# Altium schematic sheet ADC.SchDoc(ADC)
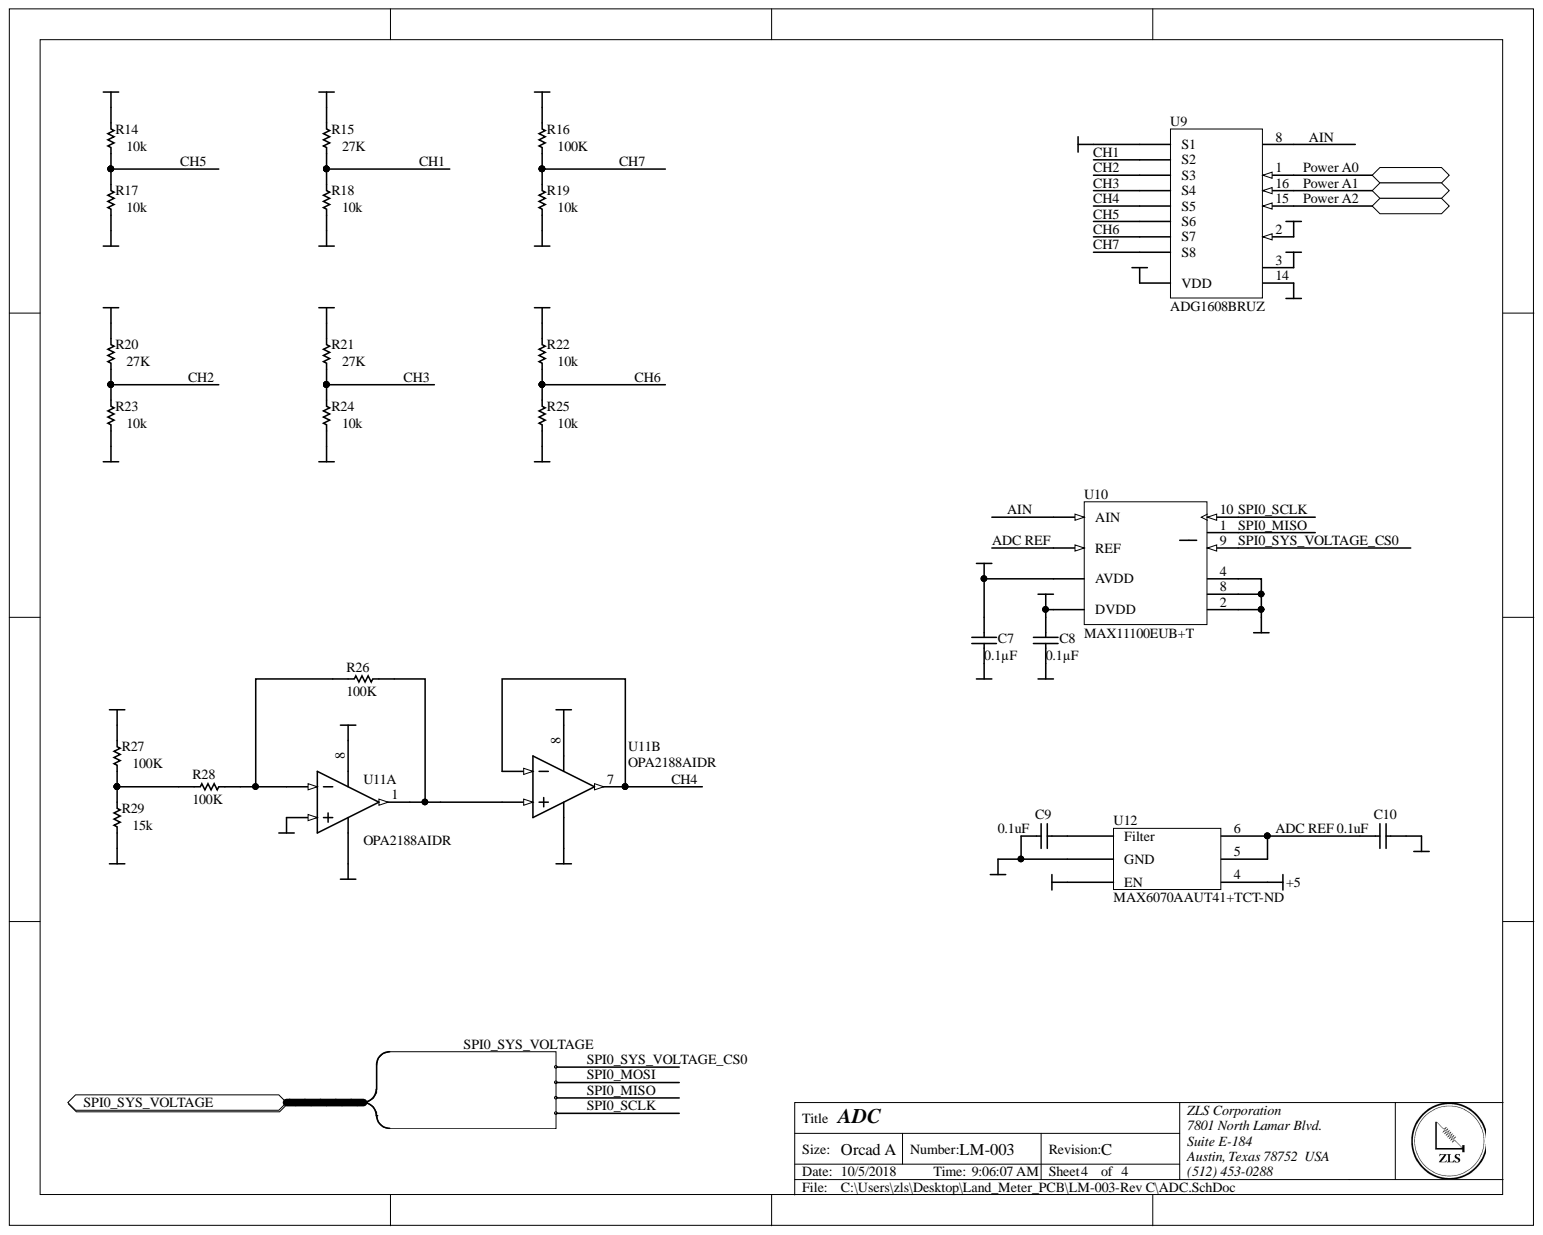
[diagram p0: ADC.SchDoc]

## Parts
C7  Capacitance: 0.1µF  [CAPC0805(2012)145_N]  (hidden comment: CAP CER 0.1UF 25V X7R 0805)
C8  Capacitance: 0.1µF  [CAPC0805(2012)145_N]  (hidden comment: CAP CER 0.1UF 25V X7R 0805)
C9  0.1uF  [CAPC0402(1005)60_L]
C10  0.1uF  [CAPC0402(1005)60_L]
R14  10k  [RESC0402(1005)_L]
R15  27K  [RESC0402(1005)_L]
R16  100K  [RESC0402(1005)_L]
R17  10k  [RESC0402(1005)_L]
R18  10k  [RESC0402(1005)_L]
R19  10k  [RESC0402(1005)_L]
R20  27K  [RESC0402(1005)_L]
R21  27K  [RESC0402(1005)_L]
R22  10k  [RESC0402(1005)_L]
R23  10k  [RESC0402(1005)_L]
R24  10k  [RESC0402(1005)_L]
R25  10k  [RESC0402(1005)_L]
R26  100K  [RESC0402(1005)_L]
R27  100K  [RESC0402(1005)_L]
R28  100K  [RESC0402(1005)_L]
R29  15k  [RESC0402(1005)_L]
U9  ADG1608BRUZ  [ADI-RU-16_M]
U10  MAX11100EUB+T  [U10+2_L]
U11  OPA2188AIDR  [D0008A_L]  (parts A,B)
U12  MAX6070AAUT41+T  Supplier Part Number 1: MAX6070AAUT41+TCT-ND  [SOT95P280X445-6N]

## Ports
Power A0, Power A1, Power A2, SPI0_SYS_VOLTAGE, SPI0_SYS_VOLTAGE.SPI0_MISO, SPI0_SYS_VOLTAGE.SPI0_MOSI, SPI0_SYS_VOLTAGE.SPI0_SCLK, SPI0_SYS_VOLTAGE.SPI0_SYS_VOLTAGE_CS0

## Nets
+3.3: C8.1 U9.4(S1) U10.3(DVDD)
+5: C7.1 R22.2 U9.2 U9.13(VDD) U10.7(AVDD) U11.8 U12.3(EN) U12.4
+5 BBB: R14.2
+12: R15.2 R27.2
-5: R29.1 U9.3 U11.4
Battery_1: R20.2
Battery_2: R21.2
GND: C7.2 C8.2 C9.2 C10.1 R17.1 R18.1 R19.1 R23.1 R24.1 R25.1 U9.14 U10.2 U10.4 U10.8 U11.3 U11.4 U12.2(GND)
ZH: R16.2
ADC REF: C10.2 U10.6(REF) U12.5 U12.6
AIN: U9.8 U10.5(AIN)
CH1: R15.1 R18.2 U9.5(S2)
CH2: R20.1 R23.2 U9.6(S3)
CH3: R21.1 R24.2 U9.7(S4)
CH4: U9.12(S5) U11.6 U11.7
CH5: R14.1 R17.2 U9.11(S6)
CH6: R22.1 R25.2 U9.10(S7)
CH7: R16.1 R19.2 U9.9(S8)
Power A0: U9.1 ; port Power A0
Power A1: U9.16 ; port Power A1
Power A2: U9.15 ; port Power A2
SPI0_MISO: U10.1 ; port SPI0_SYS_VOLTAGE
SPI0_SCLK: U10.10 ; port SPI0_SYS_VOLTAGE
SPI0_SYS_VOLTAGE_CS0: U10.9 ; port SPI0_SYS_VOLTAGE
NetC9_1: C9.1 U12.1(Filter)
NetR26_1: R26.1 U11.1 U11.5
NetR26_2: R26.2 R28.1 U11.2
NetR27_1: R27.1 R28.2 R29.2
SPI0_MOSI: ; port SPI0_SYS_VOLTAGE

## Library data of the parts
C7 C8 = CMP-1036-04795-1: Manufacturer Part Number: GRM21BR71E104KA01L; Manufacturer: Murata Electronics North America; Tolerance: ±10%; Voltage - Rated: 25V; Thickness (Max): 0.053" (1.35mm); Temperature Coefficient: X7R; Supplier Part Number 1: 490-1673-6-ND; Supplier 1: Digi-Key; Stock 1: 891652; Size / Dimension: 0.079" L x 0.049" W (2.00mm x 1.25mm); RoHS: RoHS Compliant; Ratings: -; Pricing 1: 1=0.2, 10=0.145, 100=0.0683, 500=0.04788, 1000=0.03964 (USD); Part Status: Active; Packaging: Digi-Reel®; Package / Case: 0805 (2012 Metric); Operating Temperature: -55°C ~ 125°C; Mounting Type: Surface Mount, MLCC; Library Name: Altium Content Vault; Lead Style: -; Lead Spacing: -; Height - Seated (Max): -; Features: -; Description: CAP CER 0.1UF 25V X7R 0805; ComponentLink1URL: http://www.murata.com/~/media/webrenewal/support/library/catalog/products/capacitor/mlcc/c02e.ashx; ComponentLink1Description: http://www.murata.com/~/media/webrenewal/support/library/catalog/products/capacitor/mlcc/c02e.ashx; Component Kind: Standard; Category: Capacitors; Applications: General Purpose
C9 C10 = CMP-1034-00252-1: CAP CER 0.1UF 10V X7R 0402; Manufacturer Part Number: GRM155R71A104KA01D; Manufacturer: Murata Electronics North America; Tolerance: ±10%; Voltage - Rated: 10V; Thickness (Max): 0.022" (0.55mm); Temperature Coefficient: X7R; Supplier Part Number 1: 490-6321-1-ND; Supplier 1: Digi-Key; Stock 1: 2920395; Size / Dimension: 0.039" L x 0.020" W (1.00mm x 0.50mm); RoHS: RoHS Compliant; Ratings: -; Pricing 1: 1=0.1, 10=0.014, 100=0.0063, 500=0.00446, 1000=0.00351, 2500=0.00319, 5000=0.00293 (USD); Part Status: Active; Packaging: Cut Tape (CT); Package / Case: 0402 (1005 Metric); Operating Temperature: -55°C ~ 125°C; Mounting Type: Surface Mount, MLCC; Library Name: Altium Content Vault; Lead Spacing: -; Height - Seated (Max): -; Features: -; ComponentLink1URL: http://www.murata.com/~/media/webrenewal/support/library/catalog/products/capacitor/mlcc/c02e.ashx?la=en-us; ComponentLink1Description: http://www.murata.com/~/media/webrenewal/support/library/catalog/products/capacitor/mlcc/c02e.ashx?la=en-us; Component Kind: Standard; Category: Capacitors; Applications: General Purpose
R14 R17-R19 R22-R25 = CMP-1011-00927-1: RES SMD 10K OHM 1% 1/10W 0402; Manufacturer Part Number: MCS0402MC1002FE000; Manufacturer: Vishay Beyschlag; Temperature Coefficient: ±50ppm/°C; Power (Watts): 0.1W, 1/10W; Tolerance: ±1%; Supplier Part Number 1: MCS0402-10.0K-MFCT-ND; Supplier Device Package: 0402; Supplier 1: Digi-Key; Stock 1: 167944; Size / Dimension: 0.039" L x 0.020" W (1.00mm x 0.50mm); RoHS: RoHS Compliant; Pricing 1: 1=0.3, 10=0.254, 100=0.0993, 1000=0.04158, 2500=0.03812, 5000=0.03465 (USD); Part Status: Active; Packaging: Cut Tape (CT); Package / Case: 0402 (1005 Metric); Operating Temperature: -55°C ~ 175°C; Library Name: Altium Content Vault; Height - Seated (Max): 0.015" (0.37mm); Features: Anti-Sulfur, Automotive AEC-Q200; Failure Rate: -; Composition: Thin Film; ComponentLink1URL: http://www.vishay.com/docs/28760/mcat_pro.pdf; ComponentLink1Description: http://www.vishay.com/docs/28760/mcat_pro.pdf; Component Kind: Standard; Category: Resistors
R15 R20 R21 = CMP-1011-00927-1: RES SMD 27K OHM 1% 1/10W 0402; Manufacturer Part Number: MCS04020C2702FE000; Manufacturer: Vishay Beyschlag; Temperature Coefficient: ±50ppm/°C; Power (Watts): 0.1W, 1/10W; Tolerance: ±1%; Supplier Part Number 1: 749-1569-1-ND; Supplier Device Package: 0402; Supplier 1: Digi-Key; Stock 1: 16395; Size / Dimension: 0.039" L x 0.020" W (1.00mm x 0.50mm); RoHS: RoHS Compliant; Pricing 1: 1=0.3, 10=0.254, 100=0.0993, 1000=0.04158, 2500=0.03812, 5000=0.03465 (USD); Part Status: Active; Packaging: Cut Tape (CT); Package / Case: 0402 (1005 Metric); Operating Temperature: -55°C ~ 155°C; Library Name: Altium Content Vault; Height - Seated (Max): 0.015" (0.37mm); Features: Anti-Sulfur; Failure Rate: -; Composition: Thin Film; ComponentLink1URL: http://www.vishay.com/docs/28705/mcx0x0xpro.pdf; ComponentLink1Description: http://www.vishay.com/docs/28705/mcx0x0xpro.pdf; Component Kind: Standard; Category: Resistors
R16 R26-R28 = CMP-1011-00927-1: RES SMD 100K OHM 1% 1/10W 0402; Manufacturer Part Number: MCS04020C1003FE000; Manufacturer: Vishay Beyschlag; Temperature Coefficient: ±50ppm/°C; Power (Watts): 0.1W, 1/10W; Tolerance: ±1%; Supplier Part Number 1: MCS0402-100K-CFCT-ND; Supplier Device Package: 0402; Supplier 1: Digi-Key; Stock 1: 118040; Size / Dimension: 0.039" L x 0.020" W (1.00mm x 0.50mm); RoHS: RoHS Compliant; Pricing 1: 1=0.3, 10=0.254, 100=0.0993, 1000=0.04158, 2500=0.03812, 5000=0.03465 (USD); Part Status: Active; Packaging: Cut Tape (CT); Package / Case: 0402 (1005 Metric); Operating Temperature: -55°C ~ 155°C; Library Name: Altium Content Vault; Height - Seated (Max): 0.015" (0.37mm); Features: Anti-Sulfur; Failure Rate: -; Composition: Thin Film; ComponentLink1URL: http://www.vishay.com/docs/28705/mcx0x0xpro.pdf; ComponentLink1Description: http://www.vishay.com/docs/28705/mcx0x0xpro.pdf; Component Kind: Standard; Category: Resistors
R29 = CMP-1011-00927-1: RES SMD 15K OHM 1% 1/10W 0402; Manufacturer Part Number: MCS04020C1502FE000; Manufacturer: Vishay Beyschlag; Temperature Coefficient: ±50ppm/°C; Power (Watts): 0.1W, 1/10W; Tolerance: ±1%; Supplier Part Number 1: 749-1555-1-ND; Supplier Device Package: 0402; Supplier 1: Digi-Key; Stock 1: 93804; Size / Dimension: 0.039" L x 0.020" W (1.00mm x 0.50mm); RoHS: RoHS Compliant; Pricing 1: 1=0.3, 10=0.254, 100=0.0993, 1000=0.04158, 2500=0.03812, 5000=0.03465 (USD); Part Status: Active; Packaging: Cut Tape (CT); Package / Case: 0402 (1005 Metric); Operating Temperature: -55°C ~ 155°C; Library Name: Altium Content Vault; Height - Seated (Max): 0.015" (0.37mm); Features: Anti-Sulfur; Failure Rate: -; Composition: Thin Film; ComponentLink1URL: http://www.vishay.com/docs/28705/mcx0x0xpro.pdf; ComponentLink1Description: http://www.vishay.com/docs/28705/mcx0x0xpro.pdf; Component Kind: Standard; Category: Resistors
U9 = CMP-0793-00136-1: 4.5 Ohm Ron, 8-Channel +/-5 V,+12 V, +5 V and +3.3 V Multiplexer, 16-pin SOP (RU-16), Tube; Manufacturer: Analog Devices Inc.; Voltage - Supply, Single (V+): 3.3 V ~ 16 V; Voltage - Supply, Dual (V±): ±3.3 V ~ 8 V; Switch Time (Ton, Toff) (Max): 94ns, 93ns; On-State Resistance (Max): 4.5 Ohm; Multiplexer/Demultiplexer Circuit: 8:1; Current - Leakage (IS(off)) (Max): 100pA; Crosstalk: -64dB @ 1MHz; Charge Injection: 29pC; Channel Capacitance (CS(off), CD(off)): 19pF, 117pF; Channel-to-Channel Matching (&Delta;Ron): 120 mOhm; -3db Bandwidth: 40MHz; Switch Circuit: -; Supplier Part Number 1: ADG1608BRUZ-ND; Supplier Device Package: 16-TSSOP; Supplier 1: Digi-Key; Stock 1: 1151; RoHS: RoHS Compliant; Pricing 1: 1=9.31, 96=8.01552, 192=6.95979, 576=6.0605 (USD); Part Status: Active; Packaging: Tube; Package / Case: 16-TSSOP (0.173", 4.40mm Width); Operating Temperature: -40°C ~ 125°C (TA); Manufacturer Part Number: ADG1608BRUZ; Library Name: Altium Content Vault; ComponentLink1URL: http://www.analog.com/media/en/technical-documentation/data-sheets/ADG1608_1609.pdf; ComponentLink1Description: http://www.analog.com/media/en/technical-documentation/data-sheets/ADG1608_1609.pdf; Component Kind: Standard; Category: Integrated Circuits (ICs); Base Part Number: ADG1608
U10 = CMP-0249-00017-1: IC ADC 16BIT SRL 200KSPS 10UMAX; Manufacturer: Maxim Integrated; Voltage - Supply, Digital: 2.7 V ~ 5.25 V; Voltage - Supply, Analog: 5V; Sampling Rate (Per Second): 200k; Reference Type: External; Ratio - S/H: 1:1; Number of Inputs: 1; Number of Bits: 16; Input Type: Single Ended; Data Interface: SPI; Configuration: S/H-ADC; Architecture: SAR; Supplier Part Number 1: MAX11100EUB+-ND; Supplier Device Package: 10-uMAX; Supplier 1: Digi-Key; Stock 1: 927; RoHS: RoHS Compliant; Pricing 1: 1=5.98, 10=5.855 (USD); Part Status: Active; Packaging: Tube; Package / Case: 10-TFSOP, 10-MSOP (0.118", 3.00mm Width); Operating Temperature: -40°C ~ 85°C; Manufacturer Part Number: MAX11100EUB+; Library Name: Altium Content Vault; Features: -; ComponentLink1URL: https://datasheets.maximintegrated.com/en/ds/MAX11100.pdf; ComponentLink1Description: https://datasheets.maximintegrated.com/en/ds/MAX11100.pdf; Component Kind: Standard; Category: Integrated Circuits (ICs); Base Part Number: MAX11100
U11 = CMP-0272-00241-3: 0.03 uV/degC, 6 uV Vos, Low Noise, Rail-to-Rail Output, Zero-Drift Operational Amplifier, 4 to 36 V, -40 to 105 degC, 8-pin SOIC (D8), Green (RoHS & no Sb/Br); Manufacturer: Texas Instruments; Voltage - Supply, Single/Dual (±): 4 V ~ 36 V, ±2 V ~ 18 V; Slew Rate: 0.8 V/µs; Current - Supply: 415µA; Supplier Part Number 1: 296-29454-1-ND; Supplier Device Package: 8-SOIC; Supplier 1: Digi-Key; Stock 1: 11691; RoHS: RoHS Compliant; Pricing 1: 1=3.36, 10=3.02, 100=2.4745, 500=2.10648, 1000=1.77654 (USD); Part Status: Active; Packaging: Cut Tape (CT); Package / Case: 8-SOIC (0.154", 3.90mm Width); Output Type: Rail-to-Rail; Operating Temperature: -40°C ~ 105°C; Mounting Type: Surface Mount; Manufacturer Part Number: OPA2188AIDR; Library Name: Altium Content Vault; ComponentLink1URL: http://www.ti.com/lit/ds/symlink/opa2188.pdf; ComponentLink1Description: http://www.ti.com/lit/ds/symlink/opa2188.pdf; Component Kind: Standard; Category: Integrated Circuits (ICs); Base Part Number: OPA2188; Amplifier Type: Zero-Drift
U12 = MAX6070AAUT41+T: IC VREF SERIES 4.096V SOT23; Manufacturer: Maxim Integrated; Voltage - Input: 4.3 V ~ 5.5 V; Tolerance: ±0.04%; Temperature Coefficient: 6ppm/°C; Output Type: Fixed; Noise - 10Hz to 10kHz: 9µVrms; Noise - 0.1Hz to 10Hz: 9.6µVp-p; Current - Supply: 350µA; Voltage - Output (Min/Fixed): 4.096V; Supplier Device Package: SOT-23-6; Supplier 1: Digi-Key; Stock 1: 2623; RoHS: RoHS Compliant; Reference Type: Series; Pricing 1: 1=3.41, 10=3.213, 25=2.5704, 50=2.4418, 100=2.2491, 250=2.13344, 500=2.05634 (USD); Part Status: Active; Packaging: Cut Tape (CT); Package / Case: SOT-23-6; Operating Temperature: -40°C ~ 125°C (TA); Mounting Type: Surface Mount; Manufacturer Part Number: MAX6070AAUT41+T; ComponentLink1URL: https://datasheets.maximintegrated.com/en/ds/MAX6070-MAX6071.pdf; ComponentLink1Description: https://datasheets.maximintegrated.com/en/ds/MAX6070-MAX6071.pdf; Component Kind: Standard; Category: Integrated Circuits (ICs); Library Name: ZLS_Schematic_lib.SchLib
